# Altium schematic sheet lr11xx_e742v01a.SchDoc(lr11xx_e742v01a)
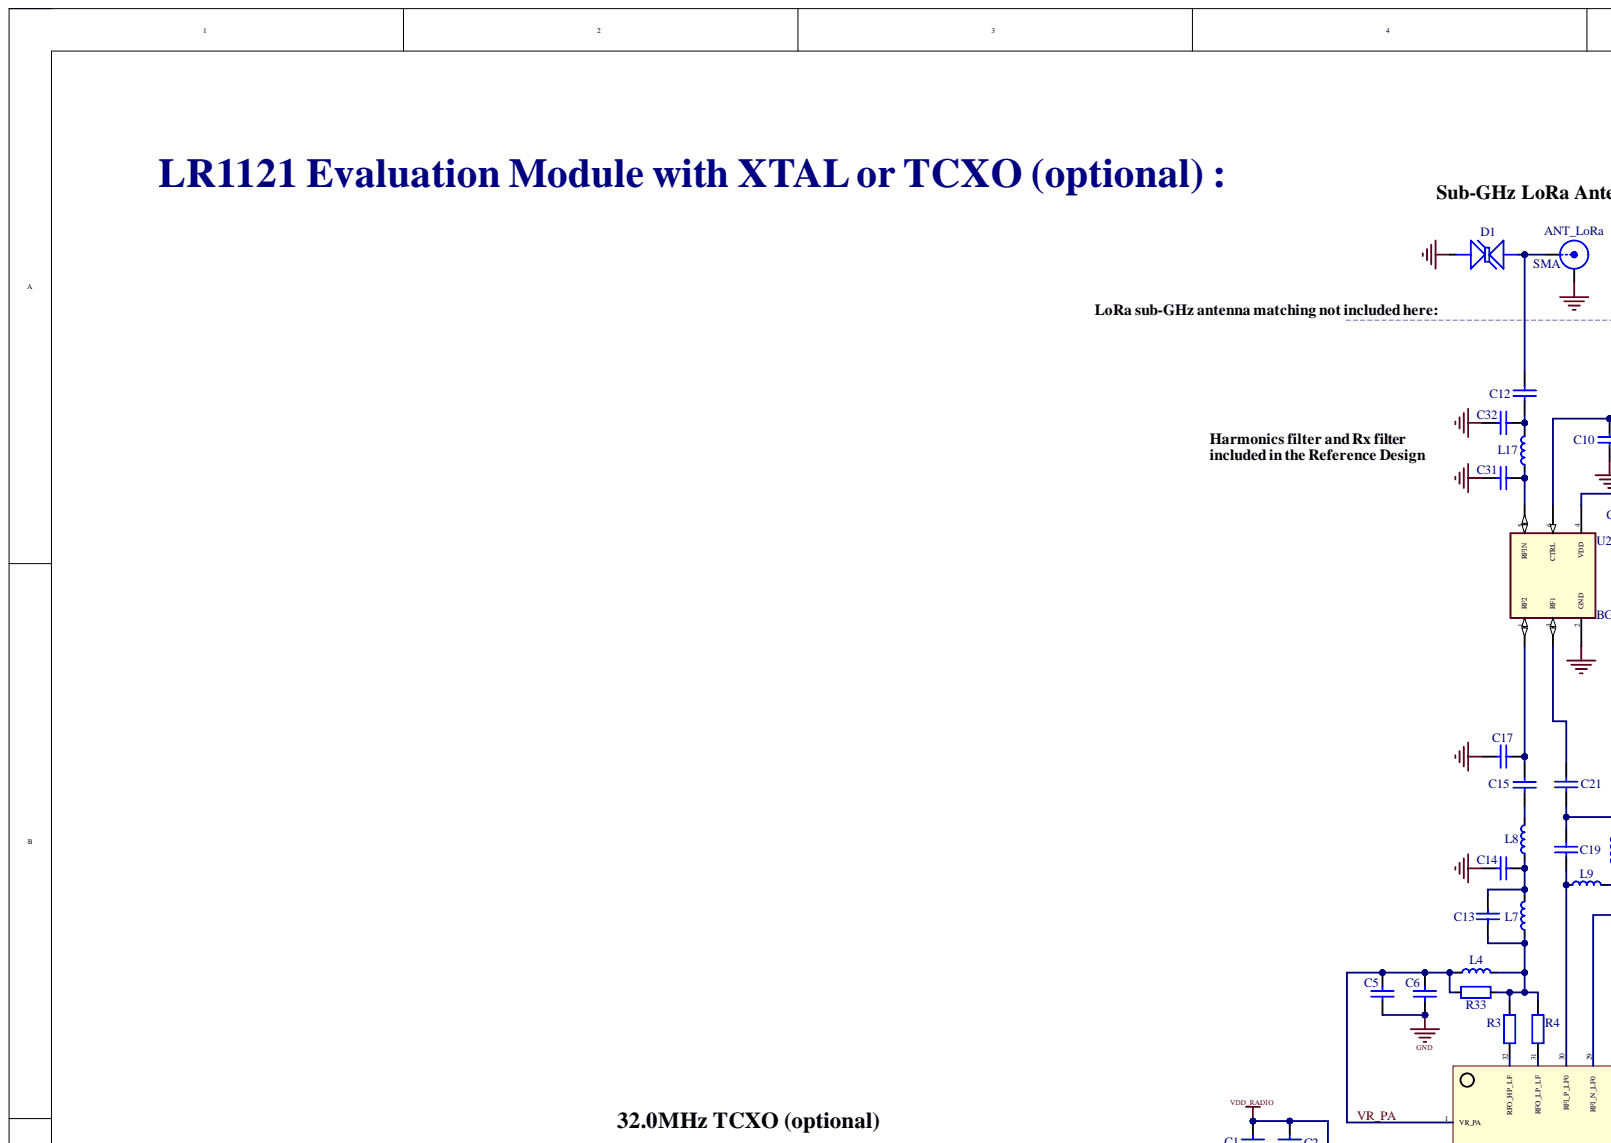
[diagram p0: lr11xx_e742v01a.SchDoc, top left]
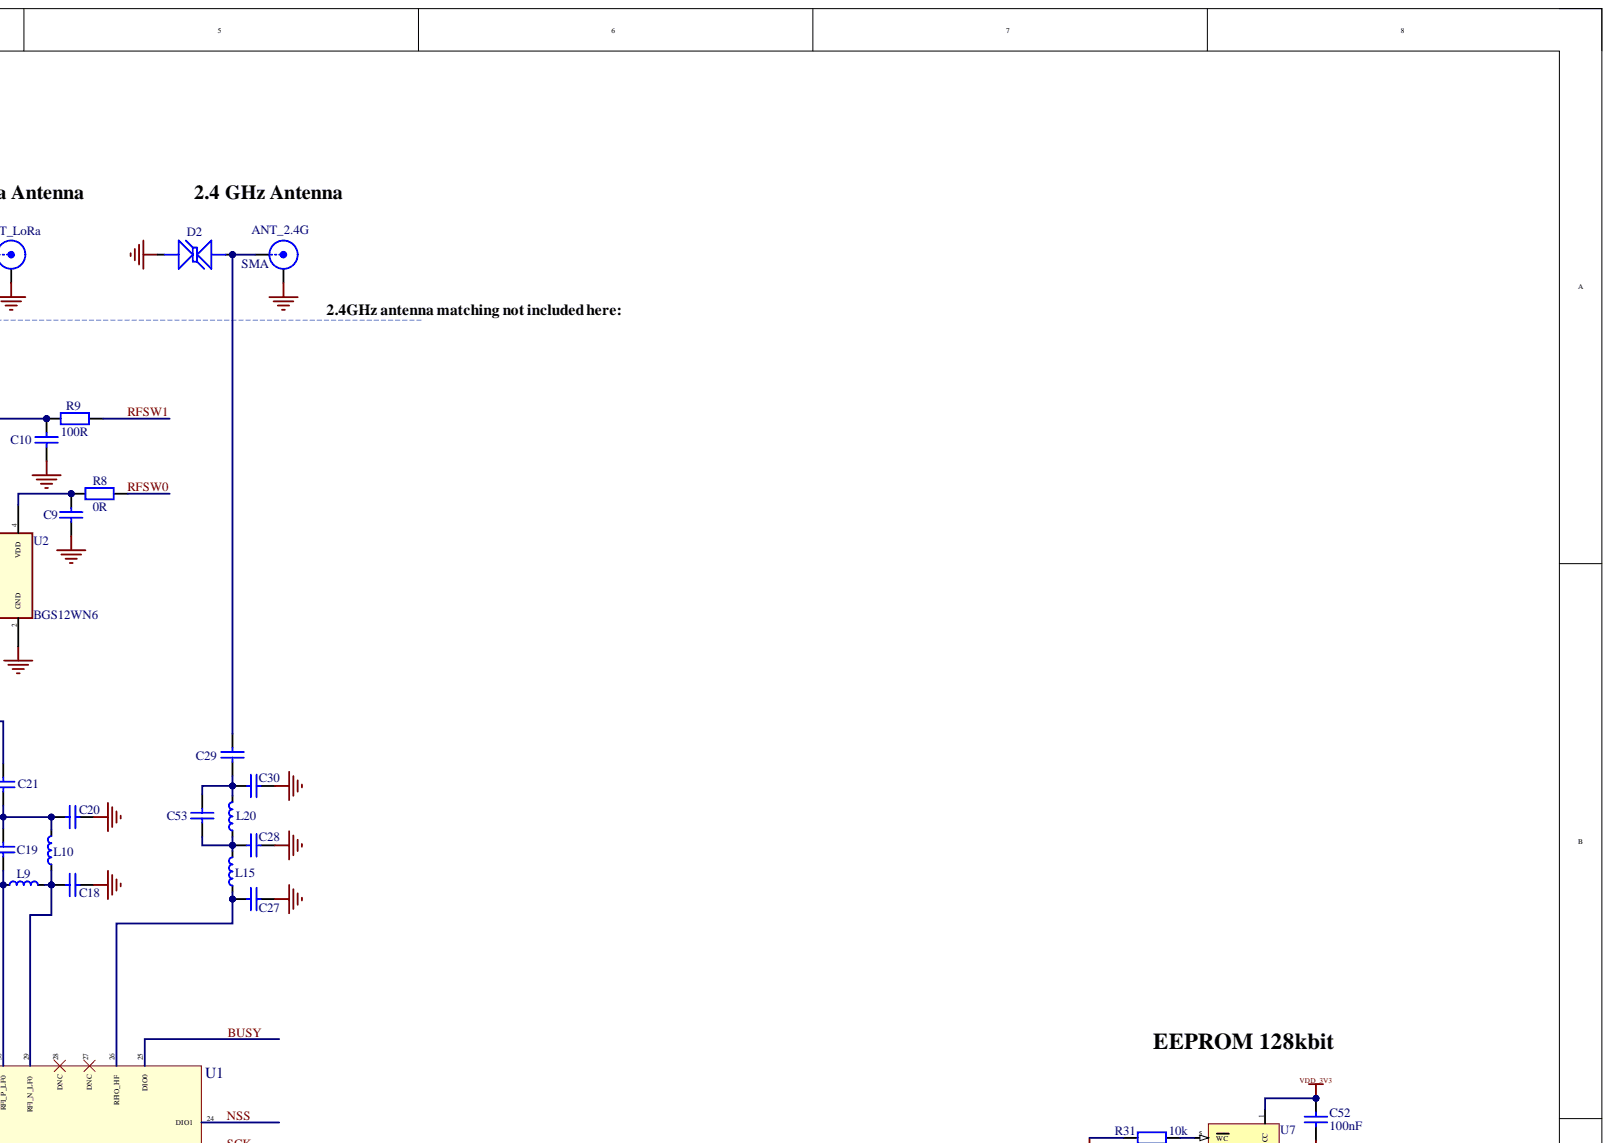
[diagram p1: lr11xx_e742v01a.SchDoc, top right]
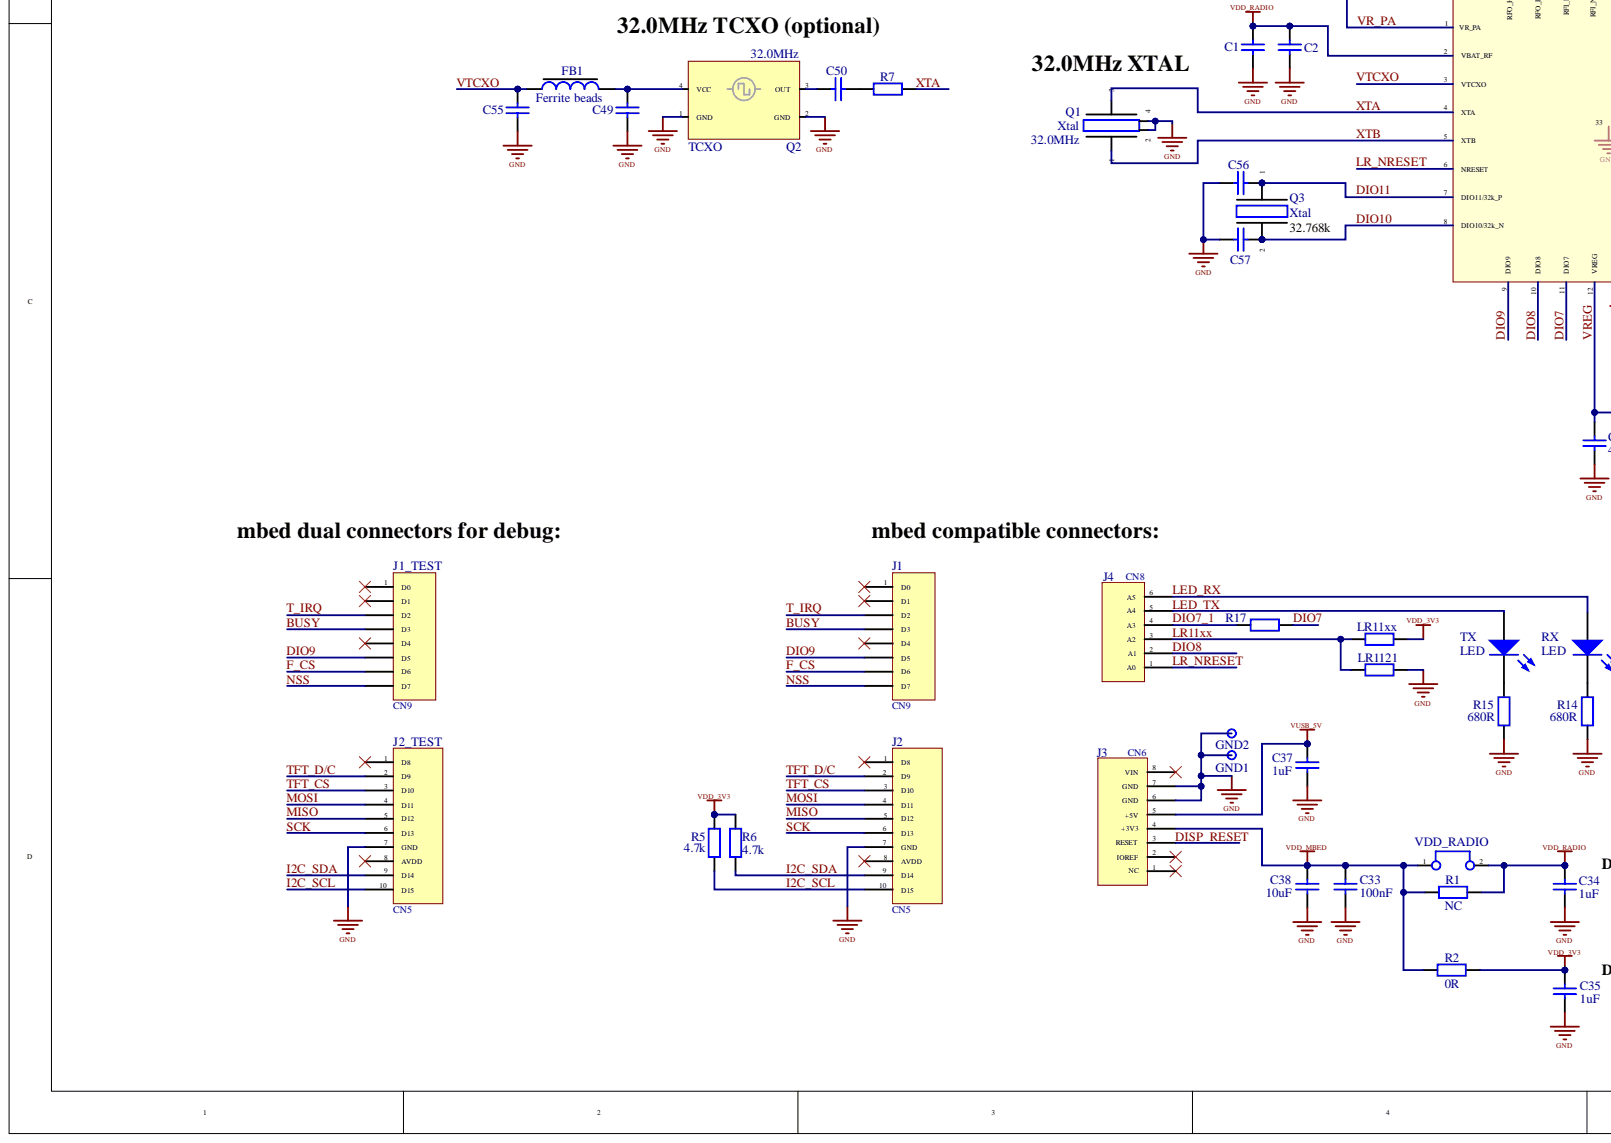
[diagram p2: lr11xx_e742v01a.SchDoc, bottom left]
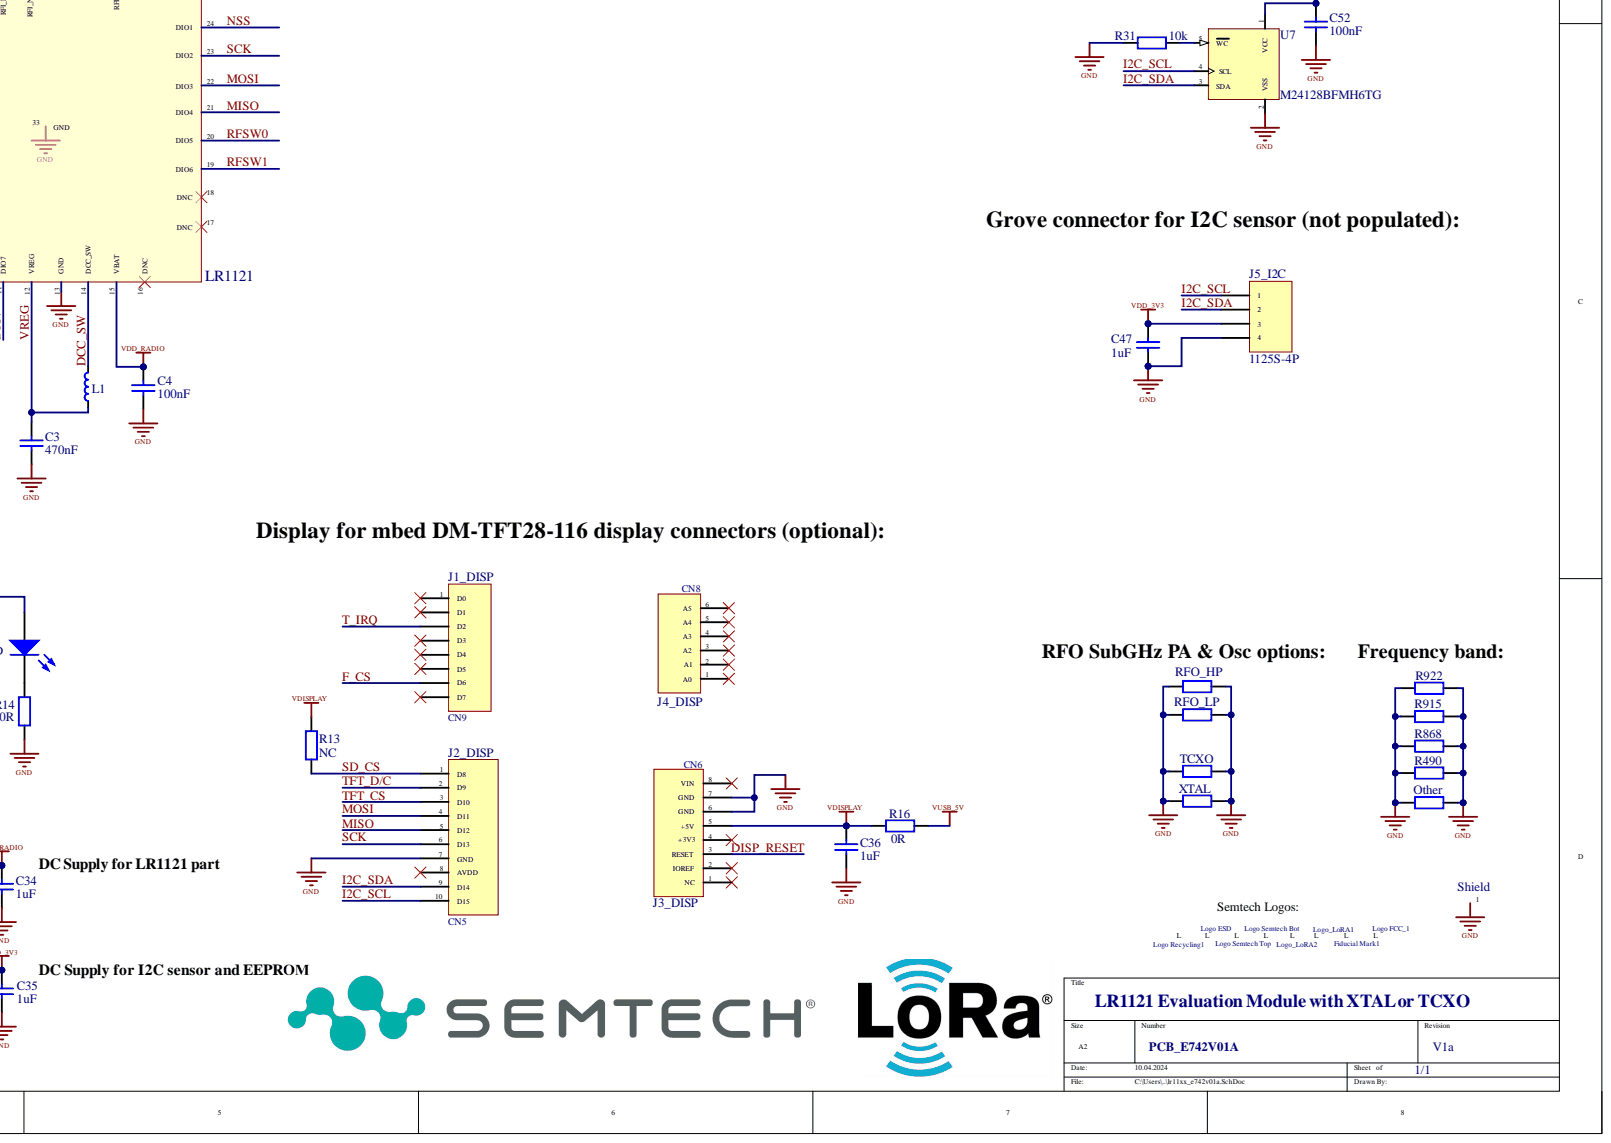
[diagram p3: lr11xx_e742v01a.SchDoc, bottom right]

## Parts
ANT_2.4G  SMA  [SMA_end_launch_1.0mm_9.52mm_female]
ANT_LoRa  SMA  [SMA_end_launch_1.0mm_9.52mm_female]
C1  Cap  [c_201_smd]  (value not drawn)
C2  Cap  [c_201_smd]  (value not drawn)
C3  value 470nF  [c_201_smd]  (hidden comment: Cap)
C4  value 100nF  [c_201_smd]  (hidden comment: Cap)
C5  Cap  [c_201_smd]  (value not drawn)
C6  Cap  [c_201_smd]  (value not drawn)
C9  Cap  [c_201_smd]  (value not drawn)
C10  Cap  [c_201_smd]  (value not drawn)
C12  Cap  [c_201_smd]  (value not drawn)
C13  Cap  [c_201_smd]  (value not drawn)
C14  Cap  [c_201_smd]  (value not drawn)
C15  Cap  [c_201_smd]  (value not drawn)
C17  Cap  [c_201_smd]  (value not drawn)
C18  Cap  [c_201_smd]  (value not drawn)
C19  Cap  [c_201_smd]  (value not drawn)
C20  Cap  [c_201_smd]  (value not drawn)
C21  Cap  [c_201_smd]  (value not drawn)
C27  Cap  [c_201_smd]  (value not drawn)
C28  Cap  [c_201_smd]  (value not drawn)
C29  Cap  [c_201_smd]  (value not drawn)
C30  Cap  [c_201_smd]  (value not drawn)
C31  Cap  [c_201_smd]  (value not drawn)
C32  Cap  [c_201_smd]  (value not drawn)
C33  value 100nF  [c_201_smd]  (hidden comment: Cap)
C34  value 1uF  [c_402_smd]  (hidden comment: Cap)
C35  value 1uF  [c_402_smd]  (hidden comment: Cap)
C36  value 1uF  [c_402_smd]  (hidden comment: Cap)
C37  value 1uF  [c_402_smd]  (hidden comment: Cap)
C38  value 10uF  [c_603_smd]  (hidden comment: Cap)
C47  value 1uF  [c_402_smd]  (hidden comment: Cap)
C49  Cap  [c_201_smd]  (value not drawn)
C50  Cap  [c_201_smd]  (value not drawn)
C52  value 100nF  [c_201_smd]  (hidden comment: Cap)
C53  Cap  [c_201_smd]  (value not drawn)
C55  Cap  [c_201_smd]  (value not drawn)
C56  Cap  [c_201_smd]  (value not drawn)
C57  Cap  [c_201_smd]  (value not drawn)
D1  TVS Diode  [SLP0603P2X3F]  (value not drawn)
D2  TVS Diode  [SLP0603P2X3F]  (value not drawn)
FB1  Ferrite beads  [Ferrite_201_smd]
Fiducial_Mark1  Logo symbol  [fiducial_mark_signal_top]  (value not drawn)
GND1  Test Pin  [pin_0.9mm]  (value not drawn)
GND2  Test Pin  [pin_0.9mm]  (value not drawn)
J1  Header 8  [HDR1X8 Arduino CN9]  (value not drawn)
J1_DISP  Header 8  [HDR1X8]  (value not drawn)
J1_TEST  Header 8  [HDR1X8_NS]  (value not drawn)
J2  Header 10  [HDR1X10 Arduino CN5]  (value not drawn)
J2_DISP  Header 10  [HDR1X10]  (value not drawn)
J2_TEST  Header 10  [HDR1X10_NS]  (value not drawn)
J3  Header 8  [HDR1X8 Arduino CN6]  (value not drawn)
J3_DISP  Header 8  [HDR1X8]  (value not drawn)
J4  Header 6  [HDR1X6 Arduino CN8]  (value not drawn)
J4_DISP  Header 6  [HDR1X6]  (value not drawn)
J5_I2C  1125S-4P  [1125S-4P_connector]
L1  Inductor  [l_603_smd]  (value not drawn)
L4  Inductor symbol  [lqw_402_smd]  (value not drawn)
L7  Inductor symbol  [LQW_201_smd]  (value not drawn)
L8  Inductor symbol  [LQW_201_smd]  (value not drawn)
L9  Inductor symbol  [LQP_201_smd]  (value not drawn)
L10  Inductor symbol  [LQP_201_smd]  (value not drawn)
L15  Inductor symbol  [LQW_201_smd]  (value not drawn)
L17  Inductor symbol  [LQW_201_smd]  (value not drawn)
L20  Inductor symbol  [LQW_201_smd]  (value not drawn)
Logo_ESD  Logo symbol  [Logo ESD]  (value not drawn)
Logo_FCC_1  Logo symbol  [Logo FCC notice]  (value not drawn)
Logo_Recycling1  Logo symbol  [Logo Recycling1]  (value not drawn)
Logo_Semtech_Bot  Logo symbol  [logo_semtech_horiz_size4]  (value not drawn)
Logo_Semtech_Top  Logo symbol  [logo_semtech_horiz_size3]  (value not drawn)
Logo_LoRA1  Logo symbol  [Logo LoRa Size3]  (value not drawn)
Logo_LoRA2  Logo symbol  [Logo LoRa Size3]  (value not drawn)
LR11xx  Resistor  [r_402_smd]  (value not drawn)
LR1121  Resistor  [r_402_smd]  (value not drawn)
Other  Resistor  [r_402_smd]  (value not drawn)
Q1  Xtal  value 32.0MHz  [XTAL_2016_1612]
Q2  TCXO  value 32.0MHz  [TCXO 2.0x1.6mm]
Q3  Xtal  value 32.768k  [XTAL_2012_SMD]
R1  value NC  [r_603_smd]  (hidden comment: Resistor)
R2  value 0R  [r_603_smd]  (hidden comment: Resistor)
R3  Resistor  [r_201_smd]  (value not drawn)
R4  Resistor  [r_201_smd]  (value not drawn)
R5  value 4.7k  [r_201_smd]  (hidden comment: Resistor)
R6  value 4.7k  [r_201_smd]  (hidden comment: Resistor)
R7  Resistor  [r_201_smd]  (value not drawn)
R8  value 0R  [r_201_smd]  (hidden comment: Resistor)
R9  value 100R  [r_201_smd]  (hidden comment: Resistor)
R13  value NC  [r_201_smd]  (hidden comment: Resistor)
R14  value 680R  [r_201_smd]  (hidden comment: Resistor)
R15  value 680R  [r_201_smd]  (hidden comment: Resistor)
R16  value 0R  [r_402_smd]  (hidden comment: Resistor)
R17  Resistor  [r_201_smd]  (value not drawn)
R31  value 10k  [r_201_smd]  (hidden comment: Resistor)
R33  Resistor small symbol  [r_201_smd]  (value not drawn)
R490  Resistor  [r_402_smd]  (value not drawn)
R868  Resistor  [r_402_smd]  (value not drawn)
R915  Resistor  [r_402_smd]  (value not drawn)
R922  Resistor  [r_402_smd]  (value not drawn)
RFO_HP  Resistor  [r_402_smd]  (value not drawn)
RFO_LP  Resistor  [r_402_smd]  (value not drawn)
RX  LED  [CD1005-0402]
Shield  RF Shield  [36103205S]  (value not drawn)
TCXO  Resistor  [r_402_smd]  (value not drawn)
TX  LED  [CD1005-0402]
U1  LR1121  [qfn32l_5x5mm]
U2  BGS12WN6  [INF-PG-TSNP-6-2-V]
U7  M24128BFMH6TG  [DFN5_1.7x1.4mm]
VDD_RADIO  Jumper  [bridge_254mm]  (value not drawn)
XTAL  Resistor  [r_402_smd]  (value not drawn)

## Nets
GND: ANT_2.4G.2 ANT_LoRa.2 C1.1 C2.1 C3.1 C4.1 C5.1 C6.1 C9.1 C10.1 C14.2 C17.2 C18.1 C20.1 C27.1 C28.1 C30.1 C31.2 C32.2 C33.1 C34.1 C35.1 C36.1 C37.1 C38.1 C47.1 C49.1 C52.1 C55.1 C56.1 C57.2 D1.1 D2.1 GND1.1 GND2.1 J2.7(GND) J2_DISP.7(GND) J2_TEST.7(GND) J3.6(GND) J3.7(GND) J3_DISP.6(GND) J3_DISP.7(GND) J5_I2C.4 LR1121.1 Other.1 Other.2 Q1.2 Q1.4 Q2.1(GND) Q2.2(GND) R14.1 R15.1 R31.1 R490.1 R490.2 R868.1 R868.2 R915.1 R915.2 R922.1 R922.2 RFO_HP.1 RFO_HP.2 RFO_LP.1 RFO_LP.2 Shield.1 TCXO.1 TCXO.2 U1.13(GND) U1.33 U2.2(GND) U7.2(VSS) XTAL.1 XTAL.2
VDD_3V3: C35.2 C47.2 C52.2 J5_I2C.3 LR11xx.1 R2.1 R5.2 R6.2 U7.1(VCC)
VDD_MBED: C33.2 C38.2 J3.4(+3V3) R1.2 R2.2 VDD_RADIO.1
VDD_RADIO: C1.2 C2.2 C4.2 C34.2 R1.1 U1.2(VBAT_RF) U1.15(VBAT) VDD_RADIO.2
VDISPLAY: C36.2 J3_DISP.5(+5V) R13.1 R16.2
VUSB_5V: C37.2 J3.5(+5V) R16.1
BUSY: J1.4(D3) J1_TEST.4(D3) U1.25
DCC_SW: L1.2 U1.14(DCC_SW)
DIO7: R17.1 U1.11
DIO7_1: J4.4(A3) R17.2
DIO8: J4.2(A1) U1.10
DIO9: J1.6(D5) J1_TEST.6(D5) U1.9(DIO9)
DIO10: C57.1 Q3.2 U1.8(DIO10/32k_N)
DIO11: C56.2 Q3.1 U1.7(DIO11/32k_P)
DISP_RESET: J3.3(RESET) J3_DISP.3(RESET)
F_CS: J1.7(D6) J1_DISP.7(D6) J1_TEST.7(D6)
I2C_SCL: J2.10(D15) J2_DISP.10(D15) J2_TEST.10(D15) J5_I2C.1 R5.1 U7.4(SCL)
I2C_SDA: J2.9(D14) J2_DISP.9(D14) J2_TEST.9(D14) J5_I2C.2 R6.1 U7.3(SDA)
LED_RX: J4.6(A5) RX.1
LED_TX: J4.5(A4) TX.1
LR11xx: J4.3(A2) LR11xx.2 LR1121.2
LR_NRESET: J4.1(A0) U1.6(NRESET)
MISO: J2.5(D12) J2_DISP.5(D12) J2_TEST.5(D12) U1.21
MOSI: J2.4(D11) J2_DISP.4(D11) J2_TEST.4(D11) U1.22
NSS: J1.8(D7) J1_TEST.8(D7) U1.24
RFSW0: R8.2 U1.20
RFSW1: R9.1 U1.19
SCK: J2.6(D13) J2_DISP.6(D13) J2_TEST.6(D13) U1.23
SD_CS: J2_DISP.1(D8) R13.2
T_IRQ: J1.3(D2) J1_DISP.3(D2) J1_TEST.3(D2)
TFT_CS: J2.3(D10) J2_DISP.3(D10) J2_TEST.3(D10)
TFT_D/C: J2.2(D9) J2_DISP.2(D9) J2_TEST.2(D9)
VR_PA: C5.2 C6.2 L4.1 R33.1 U1.1(VR_PA)
VREG: C3.2 L1.1 U1.12(VREG)
VTCXO: C55.2 FB1.1 U1.3(VTCXO)
XTA: Q1.3 R7.1 U1.4(XTA)
XTB: Q1.1 U1.5(XTB)
NetANT_2.4G_1: ANT_2.4G.1 C29.2 D2.2
NetANT_LoRa_1: ANT_LoRa.1 C12.2 D1.2
NetC9_2: C9.2 R8.1 U2.4(VDD)
NetC10_2: C10.2 R9.2 U2.6(CTRL)
NetC12_1: C12.1 C32.1 L17.2
NetC13_1: C13.1 L4.2 L7.1 R3.1 R4.1 R33.2
NetC13_2: C13.2 C14.1 L7.2 L8.1
NetC15_1: C15.1 L8.2
NetC15_2: C15.2 C17.1 U2.1(RF2)
NetC18_2: C18.2 L9.2 L10.1 U1.29(RFI_N_LF0)
NetC19_1: C19.1 L9.1 U1.30
NetC19_2: C19.2 C20.2 C21.2 L10.2
NetC21_1: C21.1 U2.3(RF1)
NetC27_2: C27.2 L15.1 U1.26(RFIO_HF)
NetC28_2: C28.2 C53.1 L15.2 L20.1
NetC29_1: C29.1 C30.2 C53.2 L20.2
NetC31_1: C31.1 L17.1 U2.5(RFIN)
NetC49_2: C49.2 FB1.2 Q2.4(VCC)
NetC50_1: C50.1 Q2.3(OUT)
NetC50_2: C50.2 R7.2
NetR3_2: R3.2 U1.32
NetR4_2: R4.2 U1.31
NetR14_2: R14.2 RX.2
NetR15_2: R15.2 TX.2
NetR31_2: R31.2 U7.5(WC)

Not connected: Fiducial_Mark1 0; J1 1(D0) 2(D1) 5(D4); J1_DISP 1(D0) 2(D1) 4(D3) 5(D4) 6(D5) 8(D7); J1_TEST 1(D0) 2(D1) 5(D4); J2 1(D8) 8(AVDD); J2_DISP 8(AVDD); J2_TEST 1(D8) 8(AVDD); J3 1(NC) 2(IOREF) 8(VIN); J3_DISP 1(NC) 2(IOREF) 4(+3V3) 8(VIN); J4_DISP 1(A0) 2(A1) 3(A2) 4(A3) 5(A4) 6(A5); Logo_ESD 0; Logo_FCC_1 0; Logo_Recycling1 0; Logo_Semtech_Bot 0; Logo_Semtech_Top 0; Logo_LoRA1 0; Logo_LoRA2 0; U1 16 17 18 27(DNC) 28(DNC)

## Library data of the parts
ANT_2.4G ANT_LoRa = SMA: SMA Straight Connector; Component Kind: Standard; LatestRevisionDate: 05-oct-2007  -  Semtech; LatestRevisionNote: Re-released for DXP Platform.; Library Name: lr11xx_e742v01a.SchLib; Publisher: Altium Limited
C1 C2 C5 C6 C9 C10 C12-C15 C17-C21 C27-C32 C49 C50 C53 C55-C57 = Cap: Capacitor SMD; Value: VAL?; Component Kind: Standard; LatestRevisionDate: 05-oct-2007  -  Semtech; LatestRevisionNote: Re-released for DXP Platform.; Library Name: lr11xx_e742v01a.SchLib; Published: 8-Jun-2000; Signal Integrity: Cap Semi; Simulation: CAP; Publisher: Altium Limited
C3 C4 C33-C38 C47 C52 = Cap: Capacitor SMD; Component Kind: Standard; LatestRevisionDate: 05-oct-2007  -  Semtech; LatestRevisionNote: Re-released for DXP Platform.; Library Name: lr11xx_e742v01a.SchLib; Published: 8-Jun-2000; Signal Integrity: Cap Semi; Simulation: CAP; Publisher: Altium Limited
D1 D2 = TVS Diode: Ultra Low Capacitance 1-line ESD Protection; Component Kind: Standard; LatestRevisionDate: 23-Aug-2016; Library Name: lr11xx_e742v01a.SchLib; Type: TVS Diode
FB1 = Ferrite beads: Value: VAL?; Component Kind: Standard; Description: Ferrite beads; Library Name: lr11xx_e742v01a.SchLib
Fiducial_Mark1 Logo_ESD Logo_FCC_1 Logo_LoRA1 Logo_LoRA2 Logo_Recycling1 Logo_Semtech_Bot Logo_Semtech_Top = Logo: Schematic symbol for Logo; Component Kind: Standard; Library Name: lr11xx_e742v01a.SchLib
GND1 GND2 = test_pin: Component Kind: Standard; Description: Test Pin; LatestRevisionDate: 05-oct-2007  -  Semtech; Library Name: lr11xx_e742v01a.SchLib
J1 J1_DISP J1_TEST = Header 8_Arduino CN9: Header, 8-Pin with Arduino pinout; D0 to D7; CN9; Component Kind: Standard; LatestRevisionDate: 17-Jul-2002; LatestRevisionNote: Re-released for DXP Platform.; Library Name: lr11xx_e742v01a.SchLib; Signal Integrity: Connector; Publisher: Altium Limited
J2 J2_DISP J2_TEST = Header 10_Arduino CN5: Header, 10-Pin with Arduino pinout; D8 to D15; CN5; Component Kind: Standard; LatestRevisionDate: 17-Jul-2002; LatestRevisionNote: Re-released for DXP Platform.; Library Name: lr11xx_e742v01a.SchLib; Signal Integrity: Connector; Publisher: Altium Limited
J3 J3_DISP = Header 8_Arduino CN6: Header, 8-Pin with Arduino pinout; DC Supply; Component Kind: Standard; LatestRevisionDate: 17-Jul-2002; LatestRevisionNote: Re-released for DXP Platform.; Library Name: lr11xx_e742v01a.SchLib; Signal Integrity: Connector; Publisher: Altium Limited
J4 J4_DISP = Header 6_Arduino CN8: Header, 6-Pin with Arduino pinout; CN8; Component Kind: Standard; LatestRevisionDate: 17-Jul-2002; LatestRevisionNote: Re-released for DXP Platform.; Library Name: lr11xx_e742v01a.SchLib; Signal Integrity: Connector; Publisher: Altium Limited
J5_I2C = 1125S-4P: 1125S-4P for Grove connector, 4-Pin; Component Kind: Standard; LatestRevisionDate: 17-Jul-2002; LatestRevisionNote: Re-released for DXP Platform.; Library Name: lr11xx_e742v01a.SchLib; Signal Integrity: Connector; Publisher: Altium Limited
L1 = Inductor: Code_IEC: 1005; Code_JEITA: 0402; Component Kind: Standard; Description: Inductor; LatestRevisionDate: 15-Jun-2021 -  Semtech; LatestRevisionNote: Re-released for DXP Platform.; Library Name: lr11xx_e742v01a.SchLib; Published: 15-Jun-2021; Value: ?; Publisher: Altium Limited
L4 L7-L10 L15 L17 L20 = Inductor: Code_IEC: 1005; Value: VAL?; Code_JEITA: 0402; Component Kind: Standard; Description: Inductor; LatestRevisionDate: 15-Jun-2021 -  Semtech; LatestRevisionNote: Re-released for DXP Platform.; Library Name: lr11xx_e742v01a.SchLib; Published: 15-Jun-2021; Publisher: Altium Limited
LR11xx LR1121 Other R3 R4 R7 R17 R490 R868 R915 R922 RFO_HP RFO_LP TCXO XTAL = Resistor: Resistor SMD - IEC symbol version; Value: VAL?; Component Kind: Standard; LatestRevisionDate: 27-Jul-20  -  Semtech; Library Name: lr11xx_e742v01a.SchLib
Q1 = Xtal GND: Crystal with GND pins; Component Kind: Standard; LatestRevisionDate: 05-oct-2007  -  Semtech; Library Name: lr11xx_e742v01a.SchLib
Q3 = Xtal: Crystal oscillator; Component Kind: Standard; LatestRevisionDate: 05-oct-2007  -  Semtech; Library Name: lr11xx_e742v01a.SchLib
R1 R2 R5 R6 R8 R9 R13-R16 R31 = Resistor: Resistor SMD - IEC symbol version; Component Kind: Standard; LatestRevisionDate: 27-Jul-20  -  Semtech; Library Name: lr11xx_e742v01a.SchLib
R33 = Resistor_small: Resistor SMD - IEC symbol version; Value: VAL?; Component Kind: Standard; LatestRevisionDate: 27-Jul-20  -  Semtech; Library Name: lr11xx_e742v01a.SchLib
Shield = Shield: RF Shield - EMI Gasket - Standard Surface Mount Shields; Component Kind: Standard; Library Name: lr11xx_e742v01a.SchLib
U1 = LR1121: LR1121 - Low Power Integrated UHF Transceiver with LoRa Modem; Component Kind: Standard; LatestRevisionDate: 22-Apr-2024 -  Semtech; Library Name: lr11xx_e742v01a.SchLib
U2 = BGS12WN6: General Purpose RF CMOS Power SPDT Switch; Manufacturer: Infineon Technologies; Component Kind: Standard; Library Name: lr11xx_e742v01a.SchLib; ManufacturerPartNumber: BGS12WN6; PackageReference: PG-TSNP-6-10; Supplier 1: Avnet; Supplier Part Number 1: BGS12WN6E6327XTSA1
U7 = M24128BFMH6TG: ST - 128-Kbit serial I²C bus EEPROM - DFN5 package; Component Kind: Standard; ComponentLink2Description: Packaging Specification; ComponentLink3Description: Microchip.com Home; ComponentLink3URL: http://www.microchip.com/; DatasheetVersion: revF, Oct-2008; Library Name: lr11xx_e742v01a.SchLib; PackageVersion: revBB, Aug-2009; Published: 2-Dec-2009; Supplier 1: DigiKey; Supplier 2: Mouser; Supplier Part Number 1: 24AA128-I/MF; Supplier Part Number 2: 511-M24128-BFMH6TG; Publisher: Altium Limited
VDD_RADIO = Jumper: Jumper Wire; Component Kind: Standard; LatestRevisionDate: 05-oct-2007  -  Semtech; Library Name: lr11xx_e742v01a.SchLib
Q2 = TCXO: Component Kind: Standard; Description: TCXO; Library Name: lr11xx_e742v01a.SchLib
RX TX = LED: Component Kind: Standard; Description: LED; LatestRevisionDate: 05-oct-2007  -  Semtech; Library Name: lr11xx_e742v01a.SchLib
